# Altium schematic sheet A315881-NFC.SchDoc(A315881-NFC)
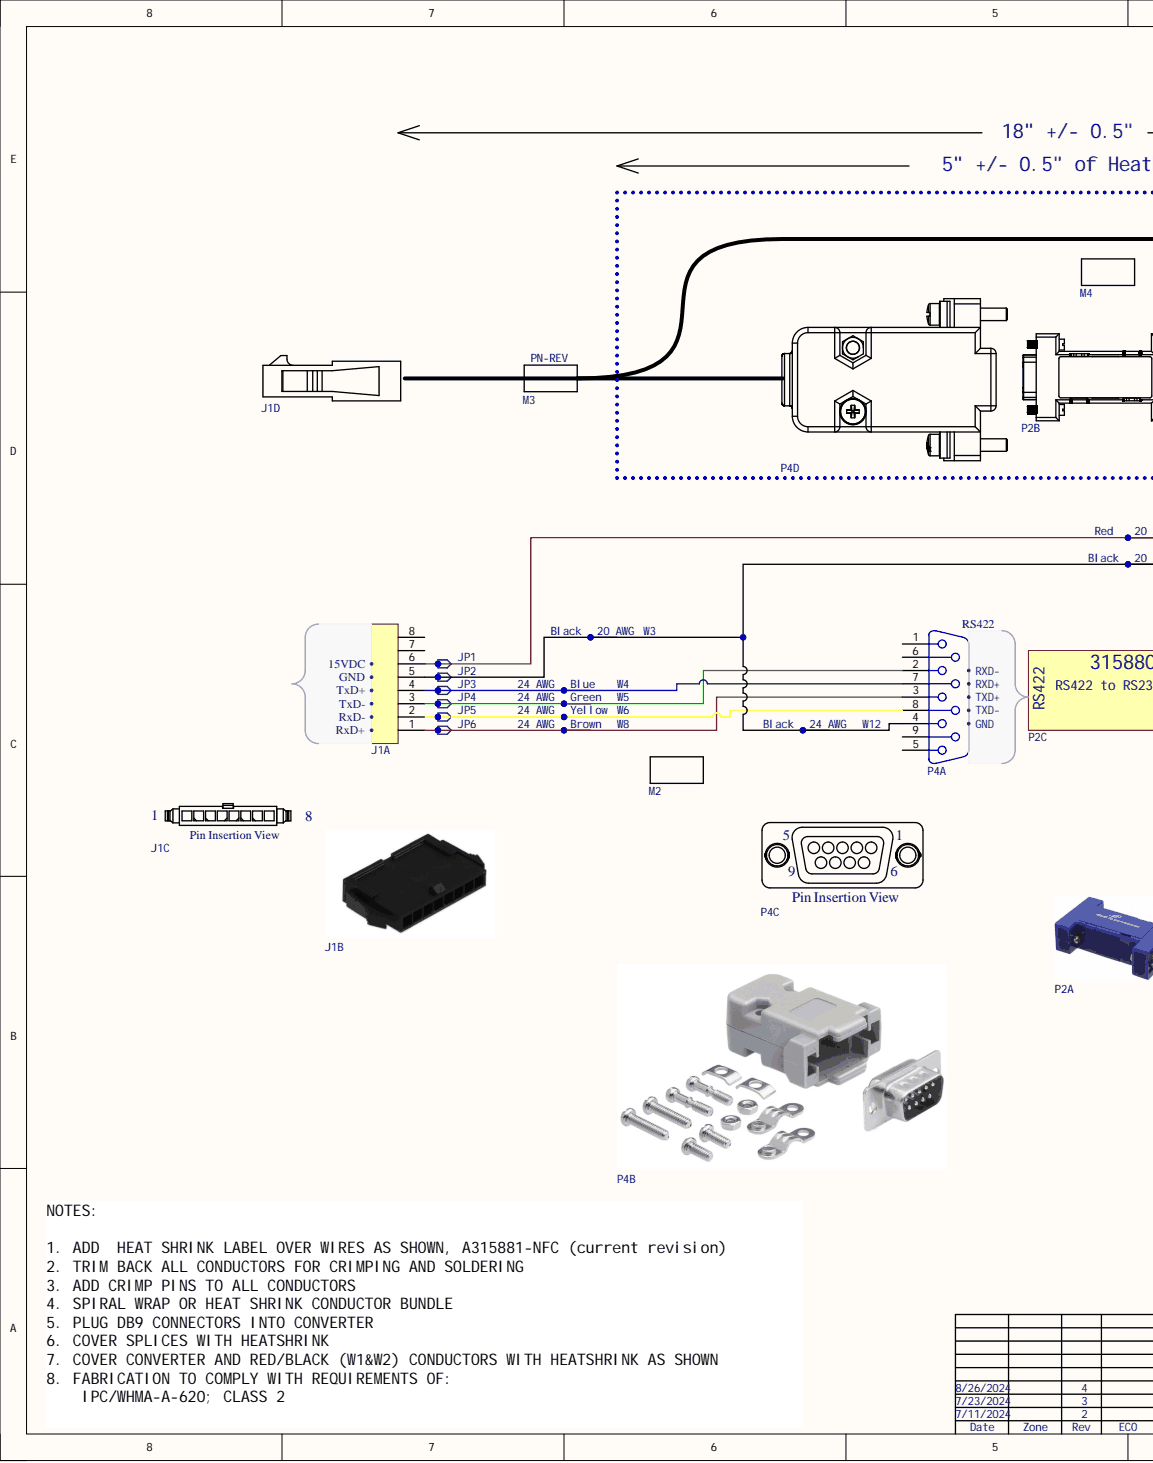
[diagram p0: A315881-NFC.SchDoc, left]
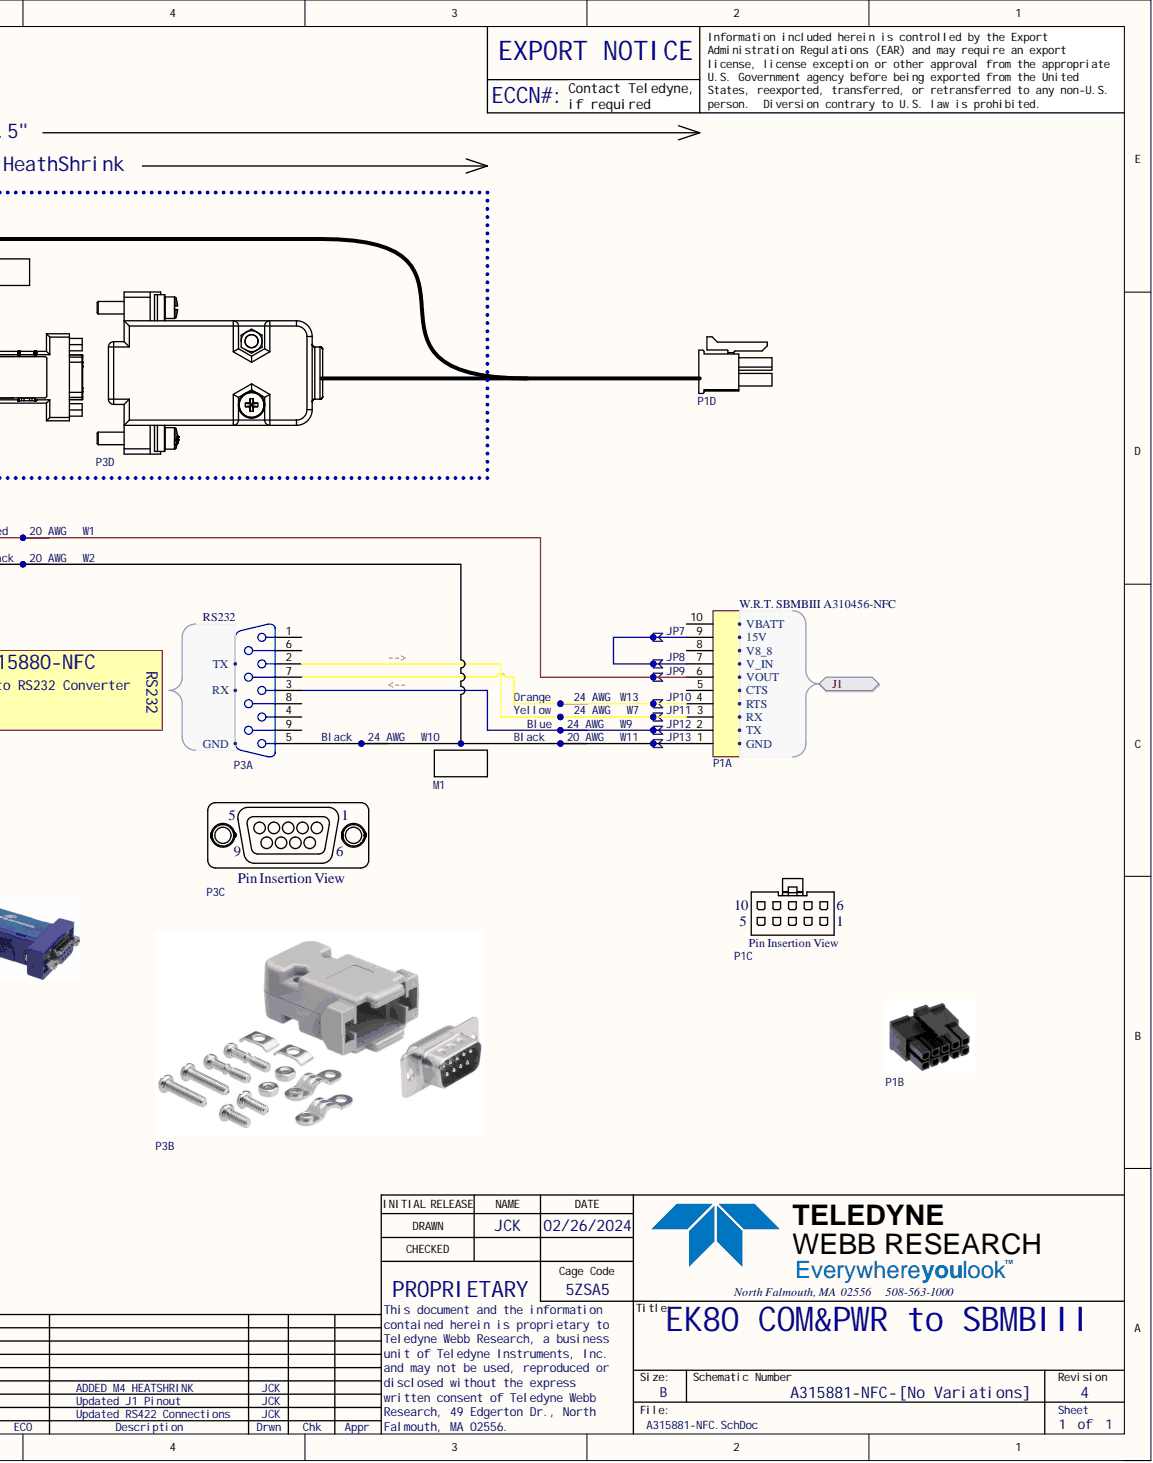
[diagram p1: A315881-NFC.SchDoc, right]

## Parts
J1  8 Rectangular Connectors - Housings Plug Black 0.118" (3.00mm)  (value not drawn)  (part A)
J1B  8 Rectangular Connectors - Housings Plug Black 0.118" (3.00mm)  (value not drawn)
J1C  8 Rectangular Connectors - Housings Plug Black 0.118" (3.00mm)  (value not drawn)
J1D  8 Rectangular Connectors - Housings Plug Black 0.118" (3.00mm)  (value not drawn)
JP1  MICROFIT TERM MALE 20-24AWG GOLD  (value not drawn)
JP2  MICROFIT TERM MALE 20-24AWG GOLD  (value not drawn)
JP3  MICROFIT TERM MALE 20-24AWG GOLD  (value not drawn)
JP4  MICROFIT TERM MALE 20-24AWG GOLD  (value not drawn)
JP5  MICROFIT TERM MALE 20-24AWG GOLD  (value not drawn)
JP6  MICROFIT TERM MALE 20-24AWG GOLD  (value not drawn)
JP7  MICROFIT TERM FEMALE 20-24AWG 30GOLD  (value not drawn)
JP8  MICROFIT TERM FEMALE 20-24AWG 30GOLD  (value not drawn)
JP9  MICROFIT TERM FEMALE 20-24AWG 30GOLD  (value not drawn)
JP10  MICROFIT TERM FEMALE 20-24AWG 30GOLD  (value not drawn)
JP11  MICROFIT TERM FEMALE 20-24AWG 30GOLD  (value not drawn)
JP12  MICROFIT TERM FEMALE 20-24AWG 30GOLD  (value not drawn)
JP13  MICROFIT TERM FEMALE 20-24AWG 30GOLD  (value not drawn)
M1  Heat Shrink Fit 600 3/8"  (value not drawn)
M2  Heat Shrink Fit 600 3/8"  (value not drawn)
M3  LABEL TAPE HEAT SHRINK 1/2" WHITE  (value not drawn)
M4  HEATSHRINK 1.45"  (value not drawn)
P1  Microfit 2x5 RECEPT 10POS  (value not drawn)  (part A)
P1B  Microfit 2x5 RECEPT 10POS  (value not drawn)
P1C  Microfit 2x5 RECEPT 10POS  (value not drawn)
P1D  Microfit 2x5 RECEPT 10POS  (value not drawn)
P2A  422_232_Converter  (value not drawn)
P2B  422_232_Converter  (value not drawn)
P2C  TeledynePN: 315880-NFC  (hidden comment: 422_232_Converter)
P3  CONN DB9 SOLDER CUP (M)  (value not drawn)  (part A)
P3B  CONN DB9 SOLDER CUP (M)  (value not drawn)
P3C  CONN DB9 SOLDER CUP (M)  (value not drawn)
P3D  CONN DB9 SOLDER CUP (M)  (value not drawn)
P4  CONN DB9 SOLDER CUP (M)  (value not drawn)  (part A)
P4B  CONN DB9 SOLDER CUP (M)  (value not drawn)
P4C  CONN DB9 SOLDER CUP (M)  (value not drawn)
P4D  CONN DB9 SOLDER CUP (M)  (value not drawn)
W1  Color: Red  Gauge: 20 AWG  (hidden comment: WIRE, 20AWG, 19/32, RED)
W2  Color: Black  Gauge: 20 AWG  (hidden comment: WIRE, 20AWG, 19/32, BLK)
W3  Color: Black  Gauge: 20 AWG  (hidden comment: WIRE, 20AWG, 19/32, BLK)
W4  Color: Blue  Gauge: 24 AWG  (hidden comment: WIRE, 24AWG, 19/36, BLU)
W5  Color: Green  Gauge: 24 AWG  (hidden comment: WIRE, 24AWG, 19/36, GRN)
W6  Color: Yellow  Gauge: 24 AWG  (hidden comment: WIRE, 24AWG, 19/36, YEL)
W7  Color: Yellow  Gauge: 24 AWG  (hidden comment: WIRE, 24AWG, 19/36, YEL)
W8  Color: Brown  Gauge: 24 AWG  (hidden comment: WIRE, 24AWG, 19/36, BRN)
W9  Color: Blue  Gauge: 24 AWG  (hidden comment: WIRE, 24AWG, 19/36, BLU)
W10  Color: Black  Gauge: 24 AWG  (hidden comment: WIRE, 24AWG, 19/36, BLK)
W11  Color: Black  Gauge: 20 AWG  (hidden comment: WIRE, 20AWG, 19/32, BLK)
W12  Color: Black  Gauge: 24 AWG  (hidden comment: WIRE, 24AWG, 19/36, BLK)
W13  Color: Orange  Gauge: 24 AWG  (hidden comment: WIRE, 24AWG, 19/36, ORG)

## Ports
J1, J1.15V, J1.CTS, J1.GND, J1.RTS, J1.RX, J1.TX, J1.V8_8, J1.V_IN, J1.VBATT, J1.VOUT

## Nets
NetJ1_1: J1.1 JP6.1 P4.3 W8.1
NetJ1_2: J1.2 JP5.1 P4.8 W6.1
NetJ1_3: J1.3 JP4.1 P4.2 W5.1
NetJ1_4: J1.4 JP3.1 P4.7 W4.1
NetJ1_5: J1.5 JP2.1 JP13.1 P1.1 P3.5 P4.4 W2.1 W3.1 W10.1 W11.1 W12.1
NetJ1_6: J1.6 JP1.1 JP9.1 P1.6 W1.1
NetJP7_1: JP7.1 JP8.1 P1.7 P1.9
NetJP10_1: JP10.1 P1.4 P3.7 W13.1
NetJP11_1: JP11.1 P1.3 P3.2 W7.1
NetJP12_1: JP12.1 P1.2 P3.3 W9.1
N00001: ; port J1
N00002: ; port J1
N00003: ; port J1
N00004: ; port J1
N00005: ; port J1
N00006: ; port J1
N00007: ; port J1
N00008: ; port J1
N00009: ; port J1
N00010: ; port J1

Not connected: J1 7 8; P1 5 8 10; P3 1 4 6 8 9; P4 1 5 6 9

## Library data of the parts
J1 J1B J1C J1D = 220: Microfit 1x8 Plug Panel; Manufacturer: Molex; ManufacturerPN: 0436400800; Database Table Name: Wiring Parts -- Connectors; ECCN Class: EAR99; TeledynePN: 313311-NFC; Callout: ?; Component Kind: Standard; ComponentLink1Description: Datasheet; ComponentLink1URL: https://www.molex.com/pdm_docs/sd/436400800_sd.pdf; ID: 220; Supplier 1: Digi-key; Supplier 2: Mouser; Supplier Part Number 1: 23-0436400800-ND; Supplier Part Number 2: 538-43640-0800; Library Name: TWR.DbLib
JP1-JP6 = 9: Manufacturer: Molex; ManufacturerPN: 43031-0002; Database Table Name: Wiring Parts -- Crimp Pins; TeledynePN: 304395; Component Kind: Standard; ComponentLink1Description: Drawing; ComponentLink1URL: http://www.molex.com/pdm_docs/sd/430310001_sd.pdf; Description: MICROFIT TERM MALE 20-24AWG GOLD; Gauge: 20-24 AWG; ID: 9; Supplier 1: Digi-Key; Supplier Part Number 1: WM1127CT-ND; Library Name: TWR.DbLib
JP7-JP13 = 7: Manufacturer: Molex; ManufacturerPN: 0430300009; Database Table Name: Wiring Parts -- Crimp Pins; TeledynePN: 302153; Component Kind: Standard; ComponentLink1Description: Drawing; ComponentLink1URL: https://www.molex.com/pdm_docs/ps/PS-43650.pdf; Description: MICROFIT TERM FEMALE 20-24AWG 30GOLD; Gauge: 20-24 AWG; ID: 7; Supplier 1: Digi-Key; Supplier Part Number 1: WM1839-ND; Library Name: TWR.DbLib
M1 M2 = 19: Manufacturer: [Teledyne PN]; ManufacturerPN: A3-004; Database Table Name: Wiring Parts -- Misc; Component Kind: Standard; Description: Heat Shrink Fit 600 3/8"; ID: 19; TeledynePN: A3-004; Library Name: TWR.DbLib
M3 = 5: Manufacturer: Rhino; ManufacturerPN: 18055; Database Table Name: Wiring Parts -- Misc; TeledynePN: 304491; Component Kind: Standard; Description: LABEL TAPE HEAT SHRINK 1/2" WHITE; ID: 5; Library Name: TWR.DbLib
M4 = 55: TUBE, HEAT SHRINK 1.45" ID TIGHT FIT 25 FEET; Manufacturer: McMaster Carr; ManufacturerPN: 9902T19; Database Table Name: Wiring Parts -- Misc; TeledynePN: 312618-NFC; Component Kind: Standard; ComponentLink1Description: Website; ComponentLink1URL: https://www.mcmaster.com/9902T19/; ID: 55; Supplier 1: McMaster Carr; Supplier Part Number 1: 9902T19; Library Name: TWR.DbLib
P1 P1B P1C P1D = 17: Manufacturer: Molex; ManufacturerPN: 43025-1000; Database Table Name: Wiring Parts -- Connectors; TeledynePN: 303475; Callout: ?; Component Kind: Standard; ComponentLink1Description: Drawing; ComponentLink1URL: http://www.molex.com/pdm_docs/sd/430250200_sd.pdf; Description: Microfit 2x5 RECEPT 10POS; ID: 17; Supplier 1: Digi-Key; Supplier Part Number 1: WM1787-ND; Library Name: TWR.DbLib
P2A P2B = 93: RS232_to_RS422; Database Table Name: Wiring Parts -- Assemblies; TeledynePN: 315880-NFC; Component Kind: Standard; ID: 93; Library Name: TWR.DbLib
P2C = 93: RS232_to_RS422; Database Table Name: Wiring Parts -- Assemblies; Component Kind: Standard; ID: 93; Library Name: TWR.DbLib
P3 P3B P3C P3D P4 P4B P4C P4D = 185: Manufacturer: McMaster; ManufacturerPN: 2146T11; Database Table Name: Wiring Parts -- Connectors; TeledynePN: E-253-1; Component Kind: Standard; ComponentLink1Description: Webbsite; ComponentLink1URL: https://www.mcmaster.com/2146T11; Description: CONN DB9 SOLDER CUP (M); ID: 185; Supplier 1: McMaster; Supplier Part Number 1: 2146T11; Library Name: TWR.DbLib
W1 = 23: Manufacturer: Pacer; ManufacturerPN: M16878/4BGE2; Database Table Name: Wiring Parts -- Wire; TeledynePN: A3-010; Component Kind: Standard; Description: WIRE, 20AWG, 19/32, RED; ID: 23; Library Name: TWR.DbLib
W2 W3 W11 = 21: Manufacturer: Pacer; ManufacturerPN: M16878/4BGE0; Database Table Name: Wiring Parts -- Wire; TeledynePN: A5-060; Component Kind: Standard; Description: WIRE, 20AWG, 19/32, BLK; ID: 21; Library Name: TWR.DbLib
W4 W9 = 7: Manufacturer: Pacer; ManufacturerPN: M16878/4BEE6; Database Table Name: Wiring Parts -- Wire; TeledynePN: A3-009; Component Kind: Standard; Description: WIRE, 24AWG, 19/36, BLU; ID: 7; Library Name: TWR.DbLib
W5 = 6: Manufacturer: Pacer; ManufacturerPN: M16878/4BEE5; Database Table Name: Wiring Parts -- Wire; TeledynePN: E-205; Component Kind: Standard; Description: WIRE, 24AWG, 19/36, GRN; ID: 6; Library Name: TWR.DbLib
W6 W7 = 5: Manufacturer: Pacer; ManufacturerPN: M16878/4BEE4; Database Table Name: Wiring Parts -- Wire; TeledynePN: A3-060; Component Kind: Standard; Description: WIRE, 24AWG, 19/36, YEL; ID: 5; Library Name: TWR.DbLib
W8 = 2: Manufacturer: Pacer; ManufacturerPN: M16878/4BEE1; Database Table Name: Wiring Parts -- Wire; TeledynePN: 301273; Component Kind: Standard; Description: WIRE, 24AWG, 19/36, BRN; ID: 2; Library Name: TWR.DbLib
W10 W12 = 1: Manufacturer: Pacer; ManufacturerPN: M16878/4BEE0; Database Table Name: Wiring Parts -- Wire; TeledynePN: E-202; Component Kind: Standard; Description: WIRE, 24AWG, 19/36, BLK; ID: 1; Library Name: TWR.DbLib
W13 = 4: Manufacturer: Pacer; ManufacturerPN: M16878/4BEE3; Database Table Name: Wiring Parts -- Wire; TeledynePN: A3-059; Component Kind: Standard; Description: WIRE, 24AWG, 19/36, ORG; ID: 4; Library Name: TWR.DbLib
